# Altium schematic sheet 07_ATK-DLMP257_LCD_CAMERA.SchDoc
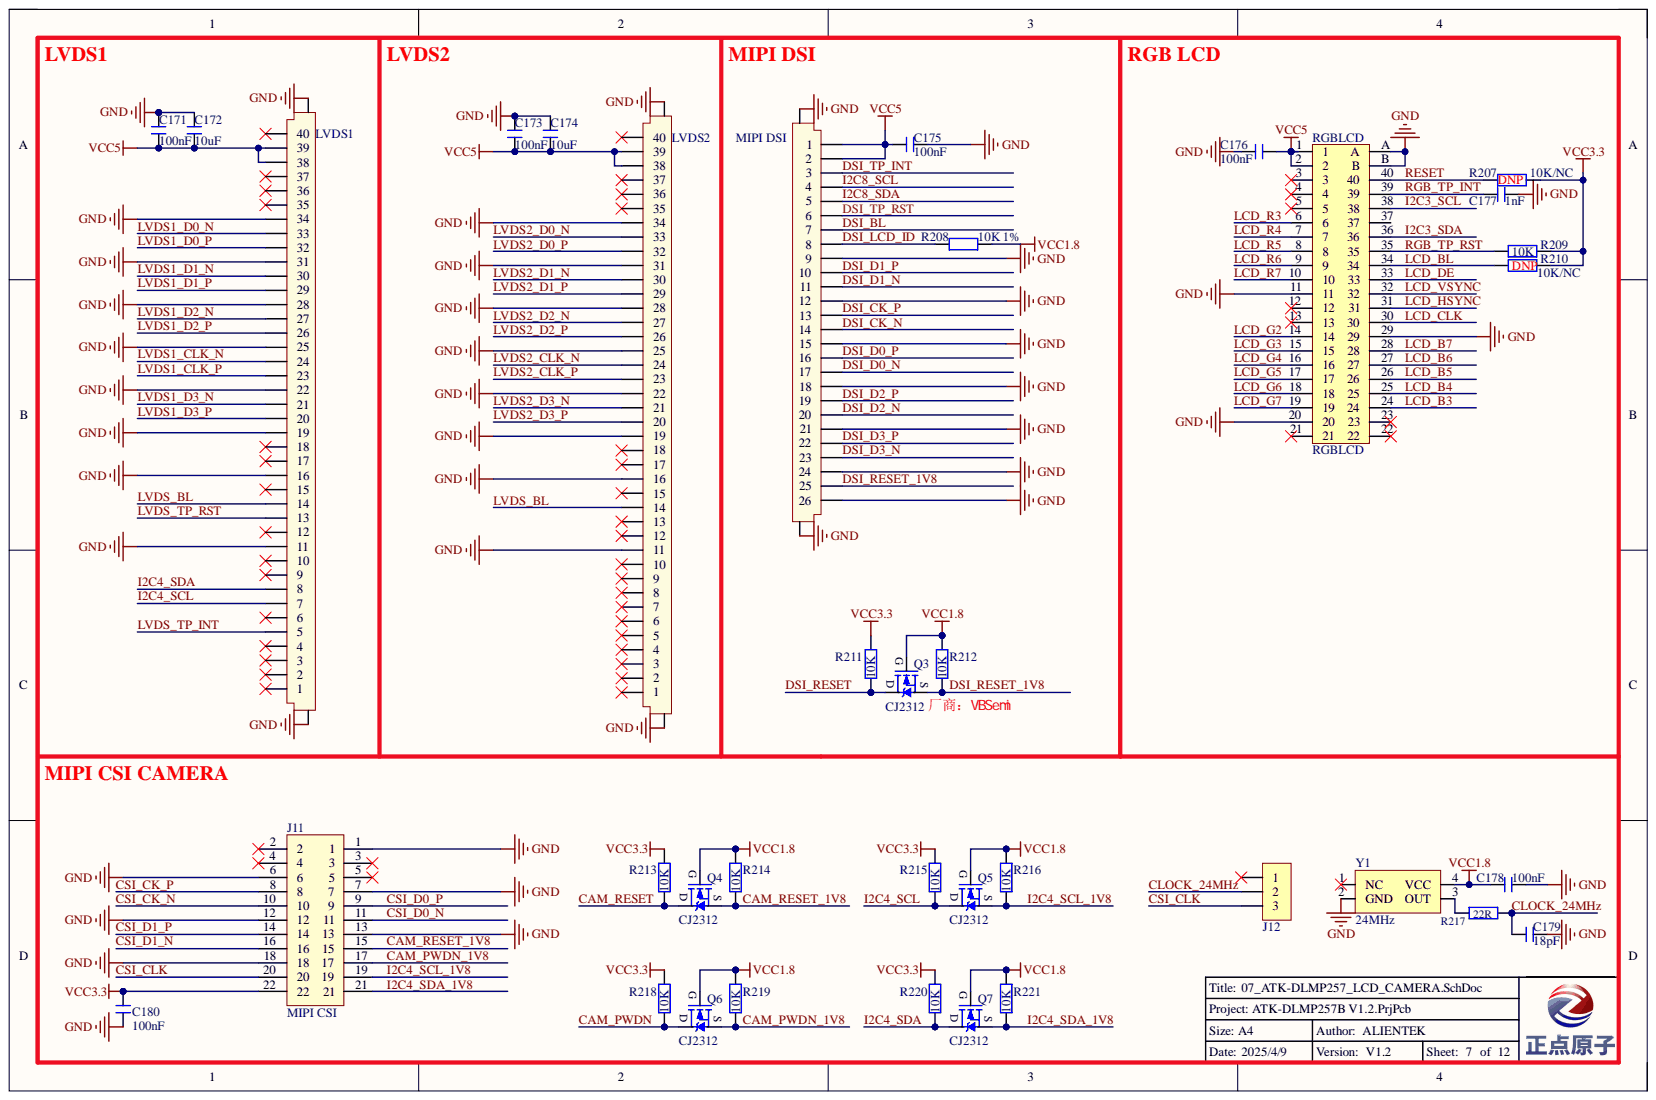
[diagram p0: 07_ATK-DLMP257_LCD_CAMERA.SchDoc]

## Parts
C171  100nF  [C 0603]
C172  10uF  [C 0603]
C173  100nF  [C 0603]
C174  10uF  [C 0603]
C175  100nF  [C 0603]
C176  100nF  [C 0603]
C177  1nF  [C 0603]
C178  100nF  [C 0603]
C179  18pF  [C 0603]
C180  100nF  [C 0603]
J11  MIPI CSI  [HEADER 2.0 2X11P]
J12  Header 1X3  [Header 2.54 1X3P]  (value not drawn)
LVDS1  FPC0.5-40P  [FPC0.5 2H-WS-40P]  (value not drawn)
LVDS2  FPC0.5-40P  [FPC0.5 2H-WS-40P]  (value not drawn)
MIPI_DSI  FPC0.5-26P  [FPC 0.5 26P V-S]  (value not drawn)
Q3  CJ2312  [SOT23-3N]
Q4  CJ2312  [SOT23-3N]
Q5  CJ2312  [SOT23-3N]
Q6  CJ2312  [SOT23-3N]
Q7  CJ2312  [SOT23-3N]
R207  10K/NC  [R 0603]
R208  10K 1%  [R 0603]
R209  10K  [R 0603]
R210  10K/NC  [R 0603]
R211  10K  [R 0603]
R212  10K  [R 0603]
R213  10K  [R 0603]
R214  10K  [R 0603]
R215  10K  [R 0603]
R216  10K  [R 0603]
R217  22R  [R 0603]
R218  10K  [R 0603]
R219  10K  [R 0603]
R220  10K  [R 0603]
R221  10K  [R 0603]
RGBLCD  RGBLCD  [FPC0.5-TOP40P]
Y1  24MHz  [XTAL-3225 - S]

## Nets
GND: C171.2 C172.2 C173.2 C174.2 C175.2 C176.1 C177.1 C178.1 C179.2 C180.1 J11.1 J11.6 J11.7 J11.12 J11.13 J11.18 LVDS1.0 LVDS1.11 LVDS1.16 LVDS1.19 LVDS1.22 LVDS1.25 LVDS1.28 LVDS1.31 LVDS1.34 LVDS2.0 LVDS2.11 LVDS2.16 LVDS2.19 LVDS2.22 LVDS2.25 LVDS2.28 LVDS2.31 LVDS2.34 MIPI_DSI.0 MIPI_DSI.9 MIPI_DSI.12 MIPI_DSI.15 MIPI_DSI.18 MIPI_DSI.21 MIPI_DSI.24 MIPI_DSI.26 RGBLCD.11 RGBLCD.20 RGBLCD.29 RGBLCD.A RGBLCD.B Y1.2(GND)
VCC1.8: C178.2 Q3.1 Q4.1 Q5.1 Q6.1 Q7.1 R208.2 R212.2 R214.2 R216.2 R219.2 R221.2 Y1.4(VCC)
VCC3.3: C180.2 J11.22 R207.1 R209.1 R210.1 R211.2 R213.2 R215.2 R218.2 R220.2
VCC5: C171.1 C172.1 C173.1 C174.1 C175.1 C176.2 LVDS1.38 LVDS1.39 LVDS2.38 LVDS2.39 MIPI_DSI.1 MIPI_DSI.2 RGBLCD.1 RGBLCD.2
CAM_PWDN: Q6.3 R218.1
CAM_PWDN_1V8: J11.17 Q6.2 R219.1
CAM_RESET: Q4.3 R213.1
CAM_RESET_1V8: J11.15 Q4.2 R214.1
CLOCK_24MHz: C179.1 J12.2 R217.1
CSI_CK_N: J11.10
CSI_CK_P: J11.8
CSI_CLK: J11.20 J12.3
CSI_D0_N: J11.11
CSI_D0_P: J11.9
CSI_D1_N: J11.16
CSI_D1_P: J11.14
DSI_BL: MIPI_DSI.7
DSI_CK_N: MIPI_DSI.14
DSI_CK_P: MIPI_DSI.13
DSI_D0_N: MIPI_DSI.17
DSI_D0_P: MIPI_DSI.16
DSI_D1_N: MIPI_DSI.11
DSI_D1_P: MIPI_DSI.10
DSI_D2_N: MIPI_DSI.20
DSI_D2_P: MIPI_DSI.19
DSI_D3_N: MIPI_DSI.23
DSI_D3_P: MIPI_DSI.22
DSI_LCD_ID: MIPI_DSI.8 R208.1
DSI_RESET: Q3.3 R211.1
DSI_RESET_1V8: MIPI_DSI.25 Q3.2 R212.1
DSI_TP_INT: MIPI_DSI.3
DSI_TP_RST: MIPI_DSI.6
I2C3_SCL: RGBLCD.38
I2C3_SDA: RGBLCD.36
I2C4_SCL: LVDS1.7 Q5.3 R215.1
I2C4_SCL_1V8: J11.19 Q5.2 R216.1
I2C4_SDA: LVDS1.8 Q7.3 R220.1
I2C4_SDA_1V8: J11.21 Q7.2 R221.1
I2C8_SCL: MIPI_DSI.4
I2C8_SDA: MIPI_DSI.5
LCD_B3: RGBLCD.24
LCD_B4: RGBLCD.25
LCD_B5: RGBLCD.26
LCD_B6: RGBLCD.27
LCD_B7: RGBLCD.28
LCD_BL: R210.2 RGBLCD.34
LCD_CLK: RGBLCD.30
LCD_DE: RGBLCD.33
LCD_G2: RGBLCD.14
LCD_G3: RGBLCD.15
LCD_G4: RGBLCD.16
LCD_G5: RGBLCD.17
LCD_G6: RGBLCD.18
LCD_G7: RGBLCD.19
LCD_HSYNC: RGBLCD.31
LCD_R3: RGBLCD.6
LCD_R4: RGBLCD.7
LCD_R5: RGBLCD.8
LCD_R6: RGBLCD.9
LCD_R7: RGBLCD.10
LCD_VSYNC: RGBLCD.32
LVDS1_CLK_N: LVDS1.24
LVDS1_CLK_P: LVDS1.23
LVDS1_D0_N: LVDS1.33
LVDS1_D0_P: LVDS1.32
LVDS1_D1_N: LVDS1.30
LVDS1_D1_P: LVDS1.29
LVDS1_D2_N: LVDS1.27
LVDS1_D2_P: LVDS1.26
LVDS1_D3_N: LVDS1.21
LVDS1_D3_P: LVDS1.20
LVDS2_CLK_N: LVDS2.24
LVDS2_CLK_P: LVDS2.23
LVDS2_D0_N: LVDS2.33
LVDS2_D0_P: LVDS2.32
LVDS2_D1_N: LVDS2.30
LVDS2_D1_P: LVDS2.29
LVDS2_D2_N: LVDS2.27
LVDS2_D2_P: LVDS2.26
LVDS2_D3_N: LVDS2.21
LVDS2_D3_P: LVDS2.20
LVDS_BL: LVDS1.14 LVDS2.14
LVDS_TP_INT: LVDS1.5
LVDS_TP_RST: LVDS1.13
RESET: R207.2 RGBLCD.40
RGB_TP_INT: C177.2 RGBLCD.39
RGB_TP_RST: R209.2 RGBLCD.35
NetR217_2: R217.2 Y1.3(OUT)

Not connected: J11 2 3 4 5; J12 1; LVDS1 1 2 3 4 6 9 10 12 15 17 18 35 36 37 40; LVDS2 1 2 3 4 5 6 7 8 9 10 12 13 15 17 18 35 36 37 40; RGBLCD 3 4 5 12 13 21 22 23 37; Y1 1(NC)

## Library data of the parts
C171-C177 C179 C180 = C: 无极性贴片电容; 版权归属: 正点原子; BOM名称: 无极性贴片电容; Component Kind: Standard; 学习资源: www.openedv.com
  C171 C173: Library Name: 电阻-电容-电感.SchLib
  C172 C174-C177 C179 C180: Library Name: Lycreturn.IntLib
C178 = C: 无极性电容; BOM名称: 无极性贴片电容; 版权归属: 正点原子; Component Kind: Standard; Library Name: 正点原子封装库V1.0.IntLib; 学习资源: www.openedv.com
J11 = HEADER 2X11P: Component Kind: Standard; Library Name: 新原理图库.SchLib
J12 = Header 3: 3P接插件; Parameter: 接插件; 版权归属: 正点原子; BOM名称: 3P接插件; Component Kind: Standard; Library Name: 正点原子封装库V1.0.IntLib; 学习资源: www.openedv.com
LVDS1 LVDS2 = FPC-40P: FPC连接器; Parameter: 接口器件; 版权归属: 正点原子; BOM名称: FPC连接器; Component Kind: Standard; 学习资源: www.openedv.com; Library Name: 连接器_FPC座.SchLib
MIPI_DSI = FPC-26P: FPC连接器; Parameter: 接口器件; 版权归属: 正点原子; BOM名称: FPC连接器; Component Kind: Standard; Library Name: 正点原子封装库V1.0.IntLib; 学习资源: www.openedv.com
Q3-Q7 = SI2302: NMOS 20V-+5.0A 55mΩ; BOM名称: N沟道场效应管; Parameter: MOS管; 版权归属: 正点原子; Component Kind: Standard; Library Name: 正点原子封装库V1.0.IntLib; 学习资源: www.openedv.com
R207 R209 R210 = R: 贴片电阻; 版权归属: 正点原子; BOM名称: 贴片电阻; Component Kind: Standard; 学习资源: www.openedv.com; Library Name: Lycreturn.IntLib
R208 R211-R216 R218-R221 = R: 电阻; BOM名称: 贴片电阻; 版权归属: 正点原子; Component Kind: Standard; Library Name: 正点原子封装库V1.0.IntLib; Parameter: 电阻; 学习资源: www.openedv.com
R217 = R: 贴片电阻; 版权归属: 源创客; BOM名称: 贴片电阻; Component Kind: Standard; 学习资源: www.ooocke.com; Library Name: Lycreturn.IntLib
RGBLCD = FPC-40: FPC-40P-0.5mm; Component Kind: Standard; Library Name: Lycreturn.IntLib
Y1 = XTAL-4P_S: 4脚有源晶振; BOM名称: 4脚晶振; Parameter: 晶振; 版权归属: 正点原子; Component Kind: Standard; Library Name: 正点原子封装库V1.0.IntLib; 学习资源: www.openedv.com
